# Altium schematic sheet PIN(9~10).SchDoc(PIN(9~10))
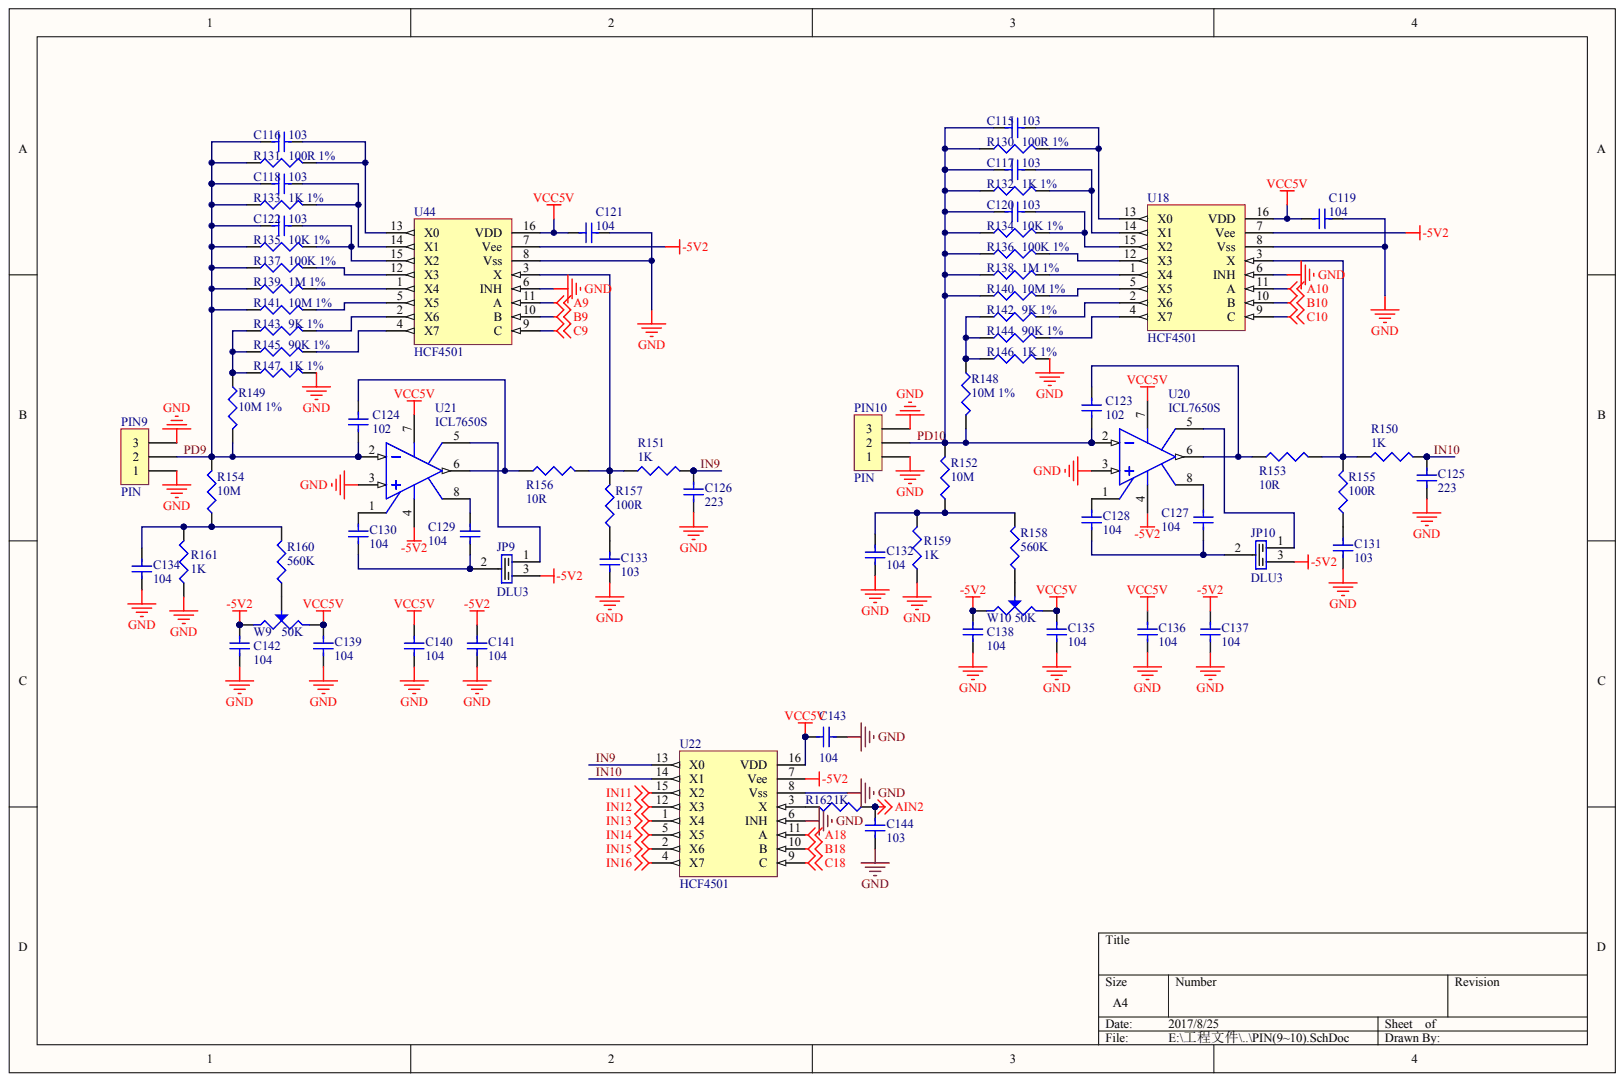
[diagram p0: PIN]

## Parts
C115  103
C116  103
C117  103
C118  103
C119  104
C120  103
C121  104
C122  103
C123  102
C124  102
C125  223
C126  223
C127  104
C128  104
C129  104
C130  104
C131  103
C132  104
C133  103
C134  104
C135  104
C136  104
C137  104
C138  104
C139  104
C140  104
C141  104
C142  104
C143  104
C144  103
JP9  DLU3
JP10  DLU3
PIN9  PIN
PIN10  PIN
R130  100R 1%
R131  100R 1%
R132  1K 1%
R133  1K 1%
R134  10K 1%
R135  10K 1%
R136  100K 1%
R137  100K 1%
R138  1M 1%
R139  1M 1%
R140  10M 1%
R141  10M 1%
R142  9K 1%
R143  9K 1%
R144  90K 1%
R145  90K 1%
R146  1K 1%
R147  1K 1%
R148  10M 1%
R149  10M 1%
R150  1K
R151  1K
R152  10M
R153  10R
R154  10M
R155  100R
R156  10R
R157  100R
R158  560K
R159  1K
R160  560K
R161  1K
R162  1K  (value not drawn)
U18  HCF4501
U20  ICL7650S
U21  ICL7650S
U22  HCF4501
U44  HCF4501
W9  50K
W10  50K

## Nets
-5V2: C137.2 C138.1 C141.2 C142.1 JP9.3 JP10.3 U18.7(Vee) U20.4 U21.4 U22.7(Vee) U44.7(Vee) W9.1 W10.1
A9: U44.11(A)
A10: U18.11(A)
A18: U22.11(A)
AIN2: C144.2 R162.1
B9: U44.10(B)
B10: U18.10(B)
B18: U22.10(B)
C9: U44.9(C)
C10: U18.9(C)
C18: U22.9(C)
GND: C119.2 C121.2 C125.1 C126.1 C131.1 C132.1 C133.1 C134.1 C135.1 C136.1 C137.1 C138.2 C139.1 C140.1 C141.1 C142.2 C143.1 C144.1 PIN9.1 PIN9.3 PIN10.1 PIN10.3 R146.2 R147.2 R159.1 R161.1 U18.6(INH) U18.8(Vss) U20.3 U21.3 U22.6(INH) U22.8(Vss) U44.6(INH) U44.8(Vss)
IN11: U22.15(X2)
IN12: U22.12(X3)
IN13: U22.1(X4)
IN14: U22.5(X5)
IN15: U22.2(X6)
IN16: U22.4(X7)
VCC5V: C119.1 C121.1 C135.2 C136.2 C139.2 C140.2 C143.2 U18.16(VDD) U20.7 U21.7 U22.16(VDD) U44.16(VDD) W9.3 W10.3
IN9: C126.2 R151.2 U22.13(X0)
IN10: C125.2 R150.2 U22.14(X1)
PD9: C116.1 C118.1 C122.1 C124.1 PIN9.2 R131.1 R133.1 R135.1 R137.1 R139.1 R141.1 R149.2 R154.2 U21.2
PD10: C115.1 C117.1 C120.1 C123.1 PIN10.2 R130.1 R132.1 R134.1 R136.1 R138.1 R140.1 R148.2 R152.2 U20.2
NetC115_2: C115.2 R130.2 U18.13(X0)
NetC116_2: C116.2 R131.2 U44.13(X0)
NetC117_2: C117.2 R132.2 U18.14(X1)
NetC118_2: C118.2 R133.2 U44.14(X1)
NetC120_2: C120.2 R134.2 U18.15(X2)
NetC122_2: C122.2 R135.2 U44.15(X2)
NetC123_2: C123.2 R153.1 U20.6
NetC124_2: C124.2 R156.1 U21.6
NetC127_1: C127.1 U20.8
NetC127_2: C127.2 C128.1 JP10.2
NetC128_2: C128.2 U20.1
NetC129_1: C129.1 U21.8
NetC129_2: C129.2 C130.1 JP9.2
NetC130_2: C130.2 U21.1
NetC131_2: C131.2 R155.1
NetC132_2: C132.2 R152.1 R158.2 R159.2
NetC133_2: C133.2 R157.1
NetC134_2: C134.2 R154.1 R160.2 R161.2
NetJP9_1: JP9.1 U21.5
NetJP10_1: JP10.1 U20.5
NetR136_2: R136.2 U18.12(X3)
NetR137_2: R137.2 U44.12(X3)
NetR138_2: R138.2 U18.1(X4)
NetR139_2: R139.2 U44.1(X4)
NetR140_2: R140.2 U18.5(X5)
NetR141_2: R141.2 U44.5(X5)
NetR142_1: R142.1 R144.1 R146.1 R148.1
NetR142_2: R142.2 U18.2(X6)
NetR143_1: R143.1 R145.1 R147.1 R149.1
NetR143_2: R143.2 U44.2(X6)
NetR144_2: R144.2 U18.4(X7)
NetR145_2: R145.2 U44.4(X7)
NetR150_1: R150.1 R153.2 R155.2 U18.3(X)
NetR151_1: R151.1 R156.2 R157.2 U44.3(X)
NetR158_1: R158.1 W10.2
NetR160_1: R160.1 W9.2
NetR162_2: R162.2 U22.3(X)
